# Altium schematic sheet ECU-5.SchDoc
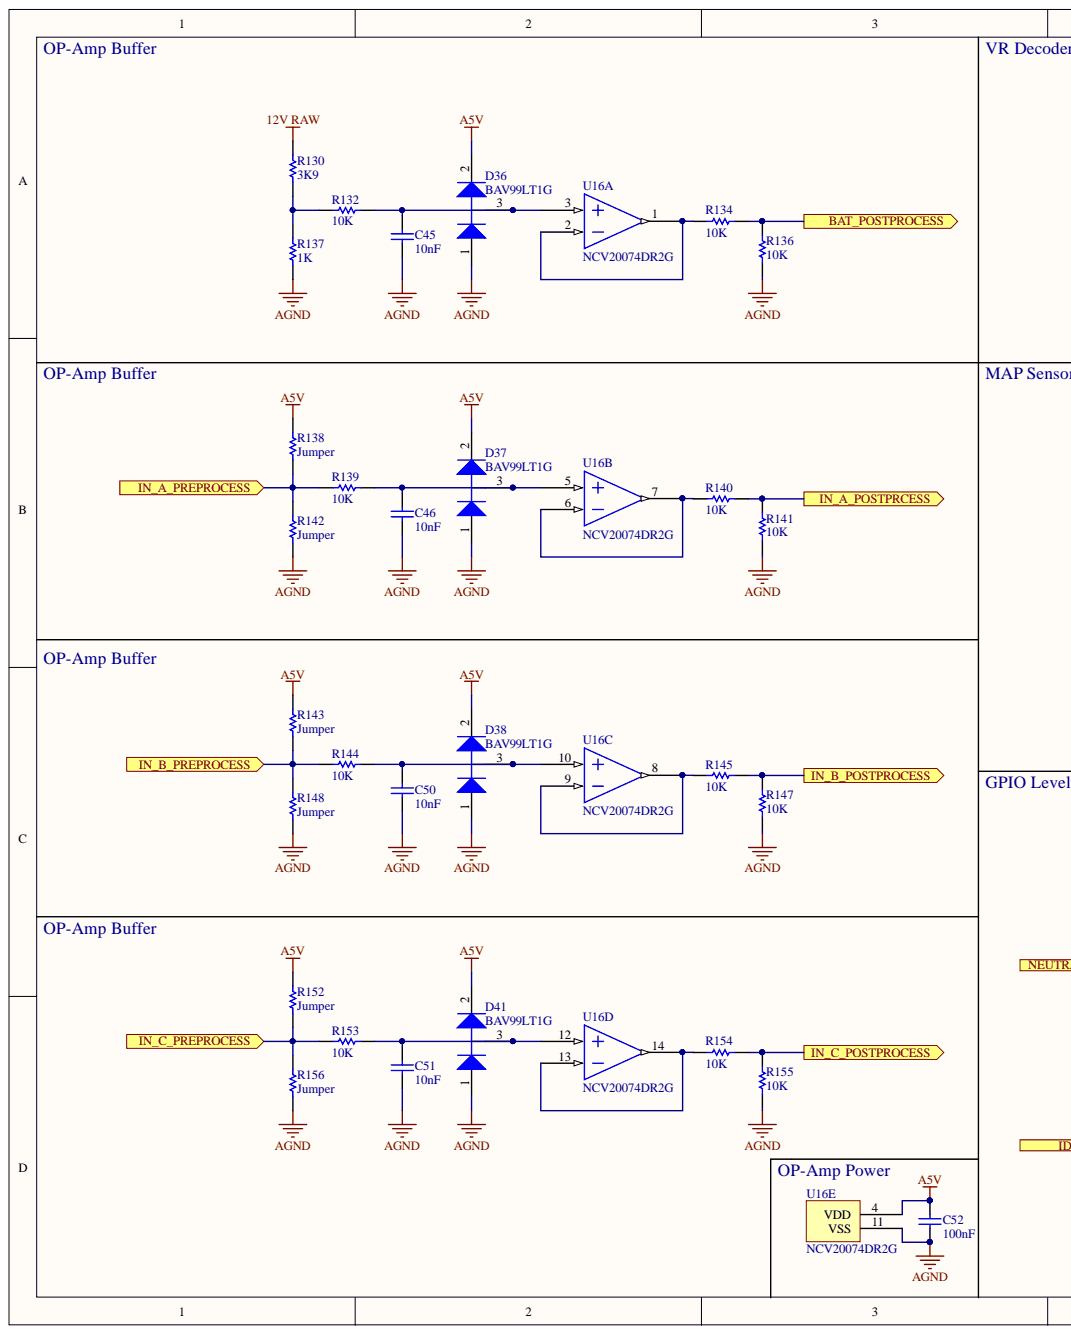
[diagram p0: ECU-5.SchDoc, left]
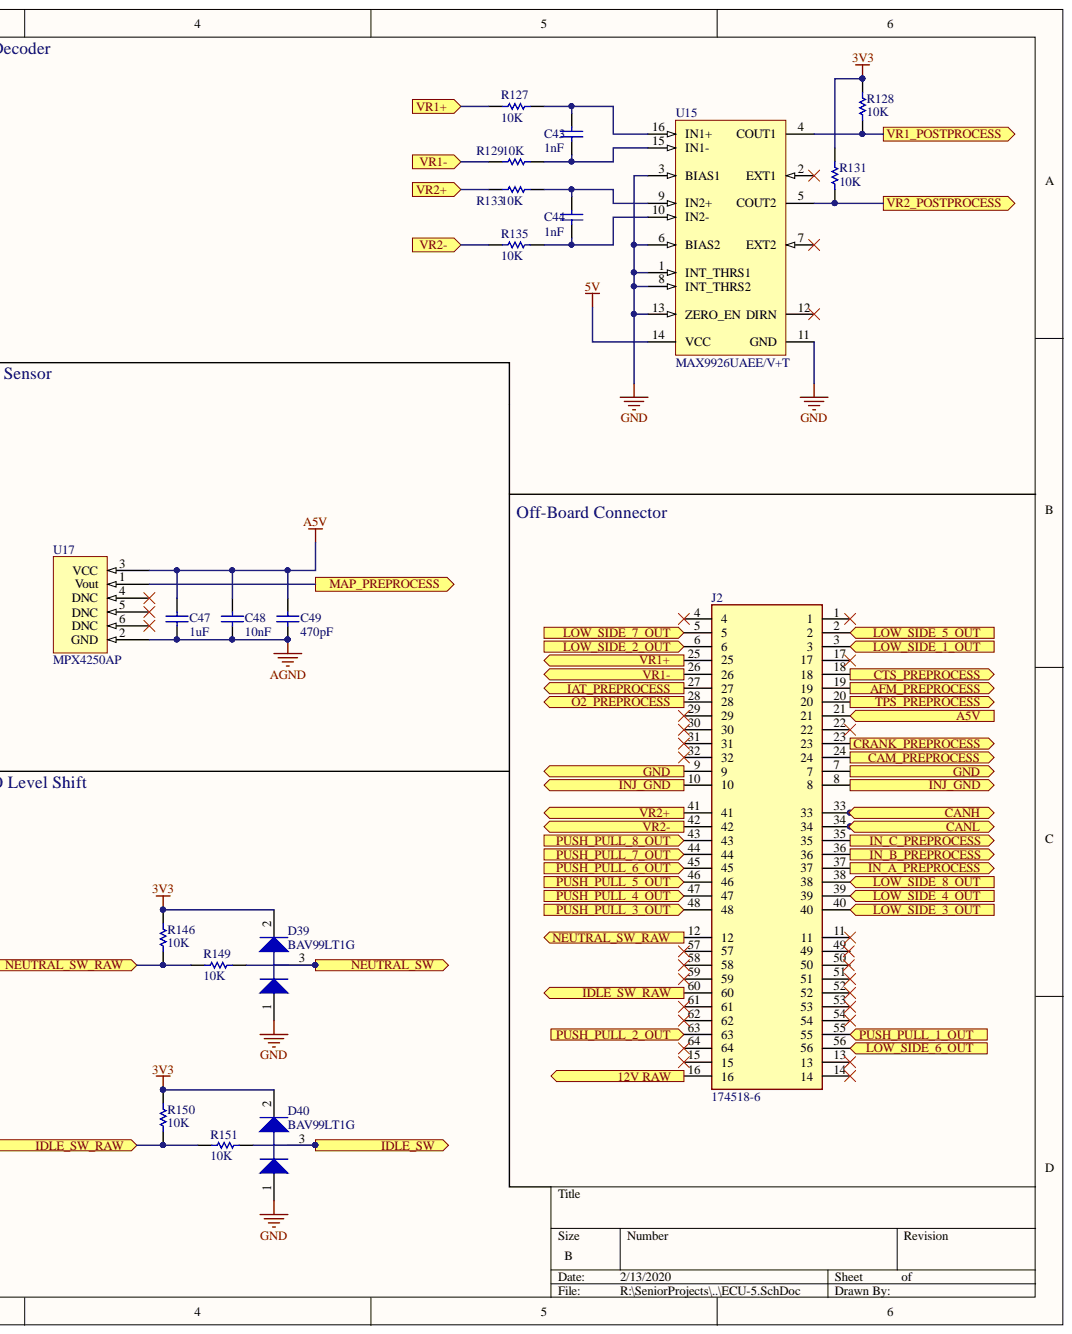
[diagram p1: ECU-5.SchDoc, right]

## Parts
C43  1nF  [CAPC0603(1608)100_N]
C44  1nF  [CAPC0603(1608)100_N]
C45  10nF  [CAPC0603(1608)100_L]
C46  10nF  [CAPC0603(1608)100_L]
C47  1uF  [CAPC0603(1608)100_L]
C48  10nF  [CAPC0603(1608)100_M]
C49  470pF  [CAPC0603(1608)100_M]
C50  10nF  [CAPC0603(1608)100_L]
C51  10nF  [CAPC0603(1608)100_L]
C52  100nF  [CAPC0603(1608)100_M]
D36  BAV99LT1G  [ONSC-SOT-23-3-318-08_V]
D37  BAV99LT1G  [ONSC-SOT-23-3-318-08_V]
D38  BAV99LT1G  [ONSC-SOT-23-3-318-08_V]
D39  BAV99LT1G  [ONSC-SOT-23-3-318-08_V]
D40  BAV99LT1G  [ONSC-SOT-23-3-318-08_V]
D41  BAV99LT1G  [ONSC-SOT-23-3-318-08_V]
J2  174518-6
R127  10K  [RESC0603(1608)_N]
R128  10K  [RESC0603(1608)_N]
R129  10K  [RESC0603(1608)_N]
R130  3K9  [RESC0603(1608)_N]
R131  10K  [RESC0603(1608)_N]
R132  10K  [RESC0603(1608)_N]
R133  10K  [RESC0603(1608)_N]  (value not drawn)
R134  10K  [RESC0603(1608)_N]
R135  10K  [RESC0603(1608)_N]
R136  10K  [RESC0603(1608)_N]
R137  1K  [RESC0603(1608)_M]
R138  Jumper  [RESC0603(1608)_N]
R139  10K  [RESC0603(1608)_N]
R140  10K  [RESC0603(1608)_N]
R141  10K  [RESC0603(1608)_N]
R142  Jumper  [RESC0603(1608)_N]
R143  Jumper  [RESC0603(1608)_N]
R144  10K  [RESC0603(1608)_N]
R145  10K  [RESC0603(1608)_N]
R146  10K  [RESC0603(1608)_N]
R147  10K  [RESC0603(1608)_N]
R148  Jumper  [RESC0603(1608)_N]
R149  10K  [RESC0603(1608)_N]
R150  10K  [RESC0603(1608)_N]
R151  10K  [RESC0603(1608)_N]
R152  Jumper  [RESC0603(1608)_N]
R153  10K  [RESC0603(1608)_N]
R154  10K  [RESC0603(1608)_N]
R155  10K  [RESC0603(1608)_N]
R156  Jumper  [RESC0603(1608)_N]
U15  MAX9926UAEE/V+T  [MAXM-E16+1_N]
U16  NCV20074DR2G  [NCV20074DR2G]  (parts A,B,C,D,E)
U17  MPX4250AP  [MPX4250AP]

## Ports
12V RAW, A5V, AFM_PREPROCESS, BAT_POSTPROCESS, CAM_PREPROCESS, CANH, CANL, CRANK_PREPROCESS, CTS_PREPROCESS, GND, IAT_PREPROCESS, IDLE_SW, IDLE_SW_RAW, IN_A_POSTPRCESS, IN_A_PREPROCESS, IN_B_POSTPROCESS, IN_B_PREPROCESS, IN_C_POSTPROCESS, IN_C_PREPROCESS, INJ_GND, LOW_SIDE_1_OUT, LOW_SIDE_2_OUT, LOW_SIDE_3_OUT, LOW_SIDE_4_OUT, LOW_SIDE_5_OUT, LOW_SIDE_6_OUT, LOW_SIDE_7_OUT, LOW_SIDE_8_OUT, MAP_PREPROCESS, NEUTRAL_SW, NEUTRAL_SW_RAW, O2_PREPROCESS, PUSH_PULL_1_OUT, PUSH_PULL_2_OUT, PUSH_PULL_3_OUT, PUSH_PULL_4_OUT, PUSH_PULL_5_OUT, PUSH_PULL_6_OUT, PUSH_PULL_7_OUT, PUSH_PULL_8_OUT, TPS_PREPROCESS, VR1+, VR1-, VR1_POSTPROCESS, VR2+, VR2-, VR2_POSTPROCESS

## Nets
3V3: D39.2 D40.2 R128.2 R131.2 R146.2 R150.2
5V: U15.14(VCC)
12V RAW: R130.2
A5V: C47.2 C48.2 C49.2 C52.2 D36.2 D37.2 D38.2 D41.2 R138.2 R143.2 R152.2 U16.4(VDD) U17.3(VCC)
AGND: C45.1 C46.1 C47.1 C48.1 C49.1 C50.1 C51.1 C52.1 D36.1 D37.1 D38.1 D41.1 R136.1 R137.1 R141.1 R142.1 R147.1 R148.1 R155.1 R156.1 U16.11(VSS) U17.2(GND)
GND: D39.1 D40.1 U15.1(INT_THRS1) U15.3(BIAS1) U15.6(BIAS2) U15.8(INT_THRS2) U15.11(GND) U15.13(ZERO_EN)
AFM_PREPROCESS: J2.19 ; port AFM_PREPROCESS
BAT_POSTPROCESS: R134.2 R136.2 ; port BAT_POSTPROCESS
CAM_PREPROCESS: J2.24 ; port CAM_PREPROCESS
CANH: J2.33 ; port CANH
CANL: J2.34 ; port CANL
CRANK_PREPROCESS: J2.23 ; port CRANK_PREPROCESS
CTS_PREPROCESS: J2.18 ; port CTS_PREPROCESS
IAT_PREPROCESS: J2.27 ; port IAT_PREPROCESS
IDLE_SW: D40.3 R151.1 ; port IDLE_SW
IDLE_SW_RAW: J2.60 R150.1 R151.2 ; port IDLE_SW_RAW
IN_A_POSTPRCESS: R140.2 R141.2 ; port IN_A_POSTPRCESS
IN_A_PREPROCESS: J2.37 R138.1 R139.1 R142.2 ; port IN_A_PREPROCESS
IN_B_POSTPROCESS: R145.2 R147.2 ; port IN_B_POSTPROCESS
IN_B_PREPROCESS: J2.36 R143.1 R144.1 R148.2 ; port IN_B_PREPROCESS
IN_C_POSTPROCESS: R154.2 R155.2 ; port IN_C_POSTPROCESS
IN_C_PREPROCESS: J2.35 R152.1 R153.1 R156.2 ; port IN_C_PREPROCESS
INJ_GND: J2.8 J2.10 ; port INJ_GND
LOW_SIDE_1_OUT: J2.3 ; port LOW_SIDE_1_OUT
LOW_SIDE_2_OUT: J2.6 ; port LOW_SIDE_2_OUT
LOW_SIDE_3_OUT: J2.40 ; port LOW_SIDE_3_OUT
LOW_SIDE_4_OUT: J2.39 ; port LOW_SIDE_4_OUT
LOW_SIDE_5_OUT: J2.2 ; port LOW_SIDE_5_OUT
LOW_SIDE_6_OUT: J2.56 ; port LOW_SIDE_6_OUT
LOW_SIDE_7_OUT: J2.5 ; port LOW_SIDE_7_OUT
LOW_SIDE_8_OUT: J2.38 ; port LOW_SIDE_8_OUT
MAP_PREPROCESS: U17.1(Vout) ; port MAP_PREPROCESS
NEUTRAL_SW: D39.3 R149.1 ; port NEUTRAL_SW
NEUTRAL_SW_RAW: J2.12 R146.1 R149.2 ; port NEUTRAL_SW_RAW
O2_PREPROCESS: J2.28 ; port O2_PREPROCESS
PUSH_PULL_1_OUT: J2.55 ; port PUSH_PULL_1_OUT
PUSH_PULL_2_OUT: J2.63 ; port PUSH_PULL_2_OUT
PUSH_PULL_3_OUT: J2.48 ; port PUSH_PULL_3_OUT
PUSH_PULL_4_OUT: J2.47 ; port PUSH_PULL_4_OUT
PUSH_PULL_5_OUT: J2.46 ; port PUSH_PULL_5_OUT
PUSH_PULL_6_OUT: J2.45 ; port PUSH_PULL_6_OUT
PUSH_PULL_7_OUT: J2.44 ; port PUSH_PULL_7_OUT
PUSH_PULL_8_OUT: J2.43 ; port PUSH_PULL_8_OUT
TPS_PREPROCESS: J2.20 ; port TPS_PREPROCESS
VR1_POSTPROCESS: R128.1 U15.4(COUT1) ; port VR1_POSTPROCESS
VR2_POSTPROCESS: R131.1 U15.5(COUT2) ; port VR2_POSTPROCESS
NetC43_1: C43.1 R127.1 U15.16(IN1+)
NetC43_2: C43.2 R129.1 U15.15(IN1-)
NetC44_1: C44.1 R133.1 U15.9(IN2+)
NetC44_2: C44.2 R135.1 U15.10(IN2-)
NetC45_2: C45.2 D36.3 R132.2 U16.3
NetC46_2: C46.2 D37.3 R139.2 U16.5
NetC50_2: C50.2 D38.3 R144.2 U16.10
NetC51_2: C51.2 D41.3 R153.2 U16.12
NetJ2_7: J2.7 J2.9 ; port GND
NetJ2_16: J2.16 ; port 12V RAW
NetJ2_21: J2.21 ; port A5V
NetJ2_25: J2.25 R127.2
NetJ2_26: J2.26 R129.2
NetJ2_41: J2.41 R133.2
NetJ2_42: J2.42 R135.2
NetR130_1: R130.1 R132.1 R137.2
NetR134_1: R134.1 U16.1 U16.2
NetR140_1: R140.1 U16.6 U16.7
NetR145_1: R145.1 U16.8 U16.9
NetR154_1: R154.1 U16.13 U16.14

Not connected: J2 1 4 11 13 14 15 17 22 29 30 31 32 49 50 51 52 53 54 57 58 59 61 62 64; U15 2(EXT1) 7(EXT2) 12(DIRN); U17 4(DNC) 5(DNC) 6(DNC)

## Library data of the parts
C43 C44 = CMP-1035-01887-1: CAP 1nF 50V ±2% 0603 (1608 Metric) Thickness 1mm SMD; Case-EIA: 0603; Case-Metric: 1608; Tolerance: Â±2%; Component Kind: Standard; Library Name: Altium Content Vault; Max Thickness: 1 mm; Rated Voltage: 50 V; Technology: SMT; Value: 1nF
C45 C46 C50 C51 = CMP-1035-02320-1: CAP 10nF 50V ±5% 0603 (1608 Metric) Thickness 1mm SMD; Case-EIA: 0603; Case-Metric: 1608; Tolerance: Â±5%; Component Kind: Standard; Library Name: Altium Content Vault; Max Thickness: 1 mm; Rated Voltage: 50 V; Technology: SMT; Value: 10nF
C47 = CMP-1035-02745-1: CAP 1uF 50V ±10% 0603 (1608 Metric) Thickness 1mm SMD; Case-EIA: 0603; Case-Metric: 1608; Tolerance: Â±10%; Component Kind: Standard; Library Name: Altium Content Vault; Max Thickness: 1 mm; Rated Voltage: 50 V; Technology: SMT; Value: 1uF
C48 = CMP-1035-02321-1: CAP 10nF 50V ±10% 0603 (1608 Metric) Thickness 1mm SMD; Case-EIA: 0603; Case-Metric: 1608; Tolerance: Â±10%; Component Kind: Standard; Library Name: Altium Content Vault; Max Thickness: 1 mm; Rated Voltage: 50 V; Technology: SMT; Value: 10nF
C49 = CMP-1035-01673-1: CAP 470pF 50V ±1% 0603 (1608 Metric) Thickness 1mm SMD; Case-EIA: 0603; Case-Metric: 1608; Tolerance: Â±1%; Component Kind: Standard; Library Name: Altium Content Vault; Max Thickness: 1 mm; Rated Voltage: 50 V; Technology: SMT; Value: 470pF
C52 = CMP-1035-02580-1: CAP 100nF 10V ±10% 0603 (1608 Metric) Thickness 1mm SMD; Case-EIA: 0603; Case-Metric: 1608; Tolerance: Â±10%; Component Kind: Standard; Library Name: Altium Content Vault; Max Thickness: 1 mm; Rated Voltage: 10 V; Technology: SMT; Value: 100nF
D36-D41 = CMP-1054-00085-1: Dual Series Switching Diodes, 3-Pin SOT-23, Pb-Free, Tape and Reel; Manufacturer: On Semiconductor; IF Max (A): 0.215; trr Max (ns): 6; Component Kind: Standard; ComponentLink1Description: Manufacturer URL; ComponentLink1URL: http://www.onsemi.com/; ComponentLink2Description: Datasheet; ComponentLink2URL: http://www.onsemi.cn/pub_link/Collateral/BAV99LT1-D.PDF; ComponentLink3Description: Package Specification; ComponentLink3URL: http://www.onsemi.cn/pub_link/Collateral/318-08.PDF; Configuration: Dual Series; DatasheetVersion: Rev. 9; Library Name: Altium Content Vault; Mounting Technology: Surface Mount; PackageDescription: 3-Pin Small Outline Transistor, Body 2.92 x 1.3 mm, Pitch 0.95 mm; PackageReference: SOT-23-3-318-08; PackageVersion: Rev. AP, 11/2009; Packing: Tape and Reel; PartNumber: BAV99LT1G; RoHS: TRUE
J2 = 174518-6: Manufacturer_Name: TE Connectivity; Manufacturer_Part_Number: 176122-6; Component Kind: Standard; Datasheet Link: https://www.te.com/commerce/DocumentDelivery/DDEController?Action=showdoc&DocId=Customer+Drawing%7F176122%7FG4%7Fpdf%7FJapanese%7FJPN_CD_176122_G4.pdf%7F176122-6; Description: 174518-6; Height: 22.2mm; Library Name: ECU.SchLib; Mouser Part Number: 571-176122-6; Mouser Price/Stock: https://www.mouser.com/Search/Refine.aspx?Keyword=571-176122-6
R127-R129 R131-R136 R139-R141 R144-R147 R149-R151 R153-R155 = CMP-1012-00623-1: 10K 0.1W 1% 0603 (1608 Metric)  SMD; Case-EIA: 0603; Case-Metric: 1608; Value: 10000.00 Ohm; Component Kind: Standard; Library Name: Altium Content Vault; Power: 0.1 W; Technology: SMT; Tolerance: 1 %
R130 = CMP-1012-00576-1: 3K9 0.1W 1% 0603 (1608 Metric)  SMD; Case-EIA: 0603; Case-Metric: 1608; Value: 3900.00 Ohm; Component Kind: Standard; Library Name: Altium Content Vault; Power: 0.1 W; Technology: SMT; Tolerance: 1 %
R137 = CMP-1012-00510-1: 1K 0.1W 1% 0603 (1608 Metric)  SMD; Case-EIA: 0603; Case-Metric: 1608; Value: 1000.00 Ohm; Component Kind: Standard; Library Name: Altium Content Vault; Power: 0.1 W; Technology: SMT; Tolerance: 1 %
R138 R142 R143 R148 R152 R156 = CMP-1012-00001-1: Jumper 0603 (1608 Metric); Case-EIA: 0603; Case-Metric: 1608; Power: None; Value: 0.00 Ohm; Component Kind: Standard; Library Name: Altium Content Vault; Technology: SMT; Tolerance: None
U15 = CMP-0253-00189-1: Dual-Channel, Variable Reluctance Sensor Interface with No Low Power Shutdown, 4.5 to 5.5 V, -40 to 125 degC, 16-Pin SOP (E16+1), Pb-Free, Tape and Reel; Channels: 2; Industry Qualified: Automotive - General; Code_JEDEC: MO137; Component Kind: Standard; ComponentLink1Description: Manufacturer URL; ComponentLink1URL: http://www.maximintegrated.com/; ComponentLink2Description: Datasheet; ComponentLink2URL: http://datasheets.maximintegrated.com/en/ds/MAX9924-MAX9927.pdf; ComponentLink3Description: Package Specification; ComponentLink3URL: http://pdfserv.maximintegrated.com/package_dwgs/21-0055.PDF; DatasheetVersion: Rev. 4; Generic Part Number: MAX9926; Library Name: Altium Content Vault; Low Pwr. Shutdn: No; Mounting Technology: Surface Mount; PackageDescription: 16-Pin Quarter Small-Outline Package (E16+1), Body 4.90 x 3.89 mm, Pitch 0.635 mm; PackageReference: E16+1; PackageVersion: Rev. K, 04/2012; Packing: Tape and Reel; PartNumber: MAX9926UAEE/V+T
U17 = MPX4250: Component Kind: Standard; Description: MPX4250AP; Library Name: ECU.SchLib
U16 = NCV20074DR2G: Component Kind: Standard; Description: NCV20074DR2G; Library Name: ECU.SchLib
